# Altium schematic sheet AMU-S26-V00-DAUGHTERShutdown.SchDoc
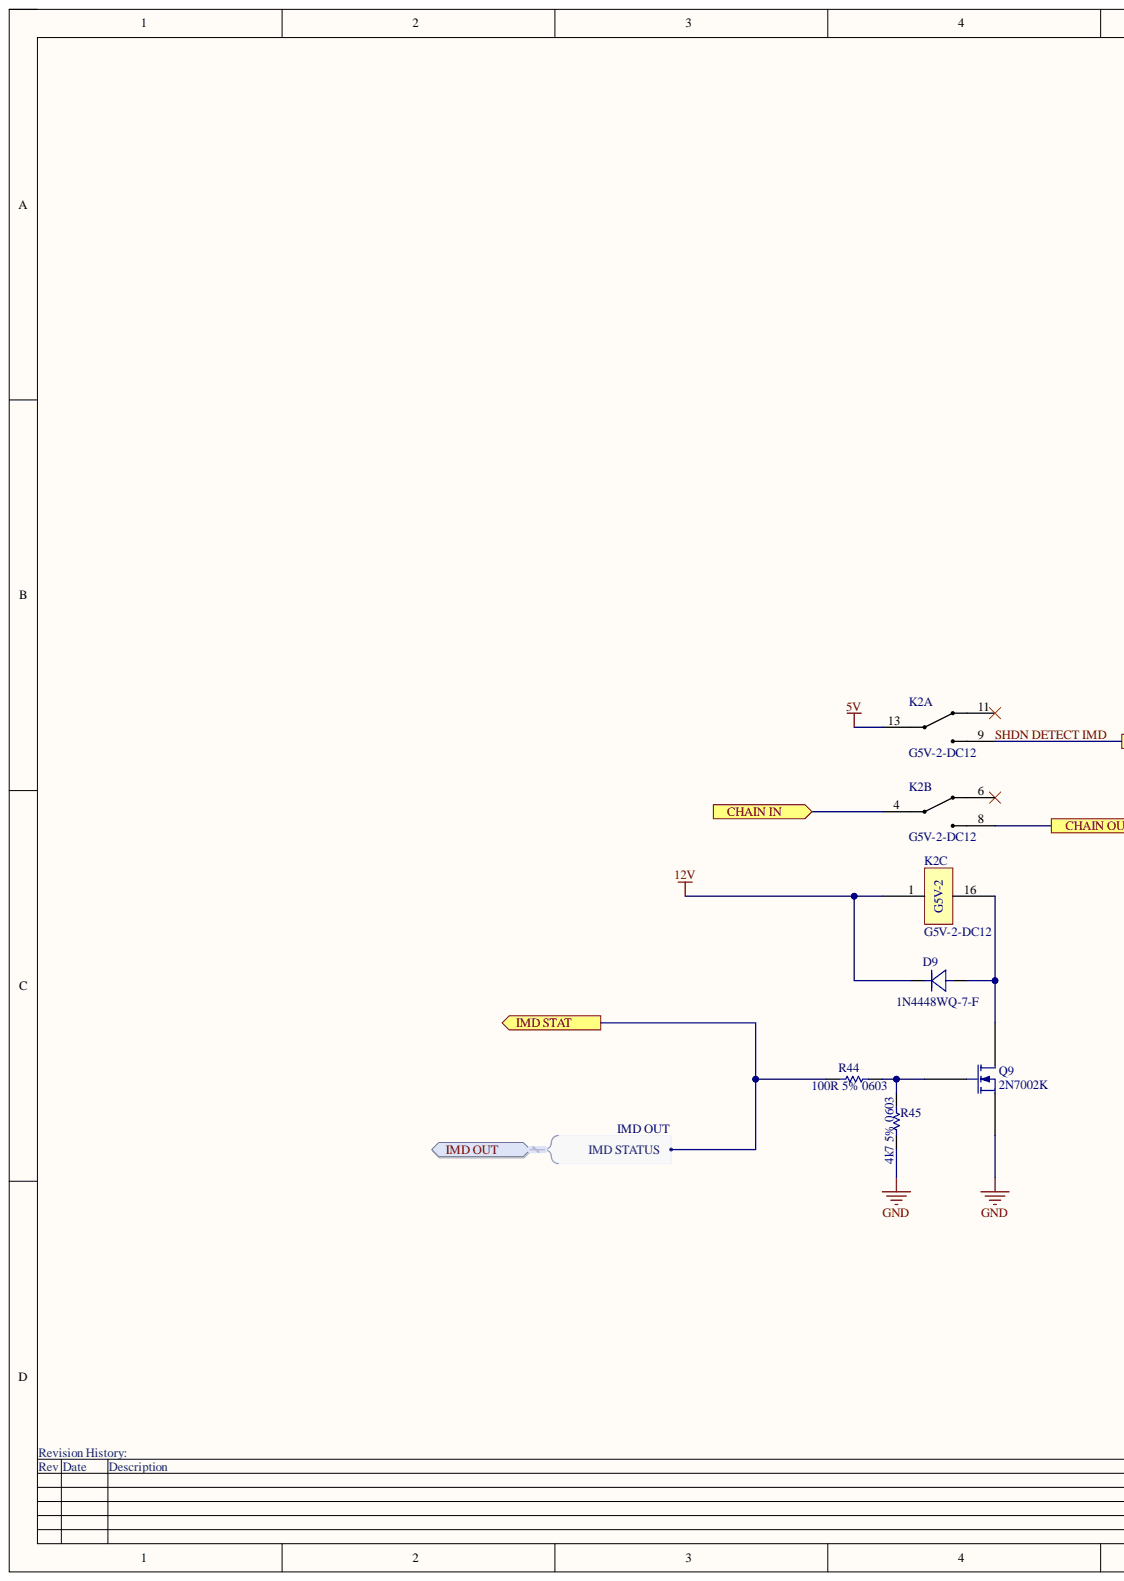
[diagram p0: AMU-S26-V00-DAUGHTERShutdown.SchDoc, left]
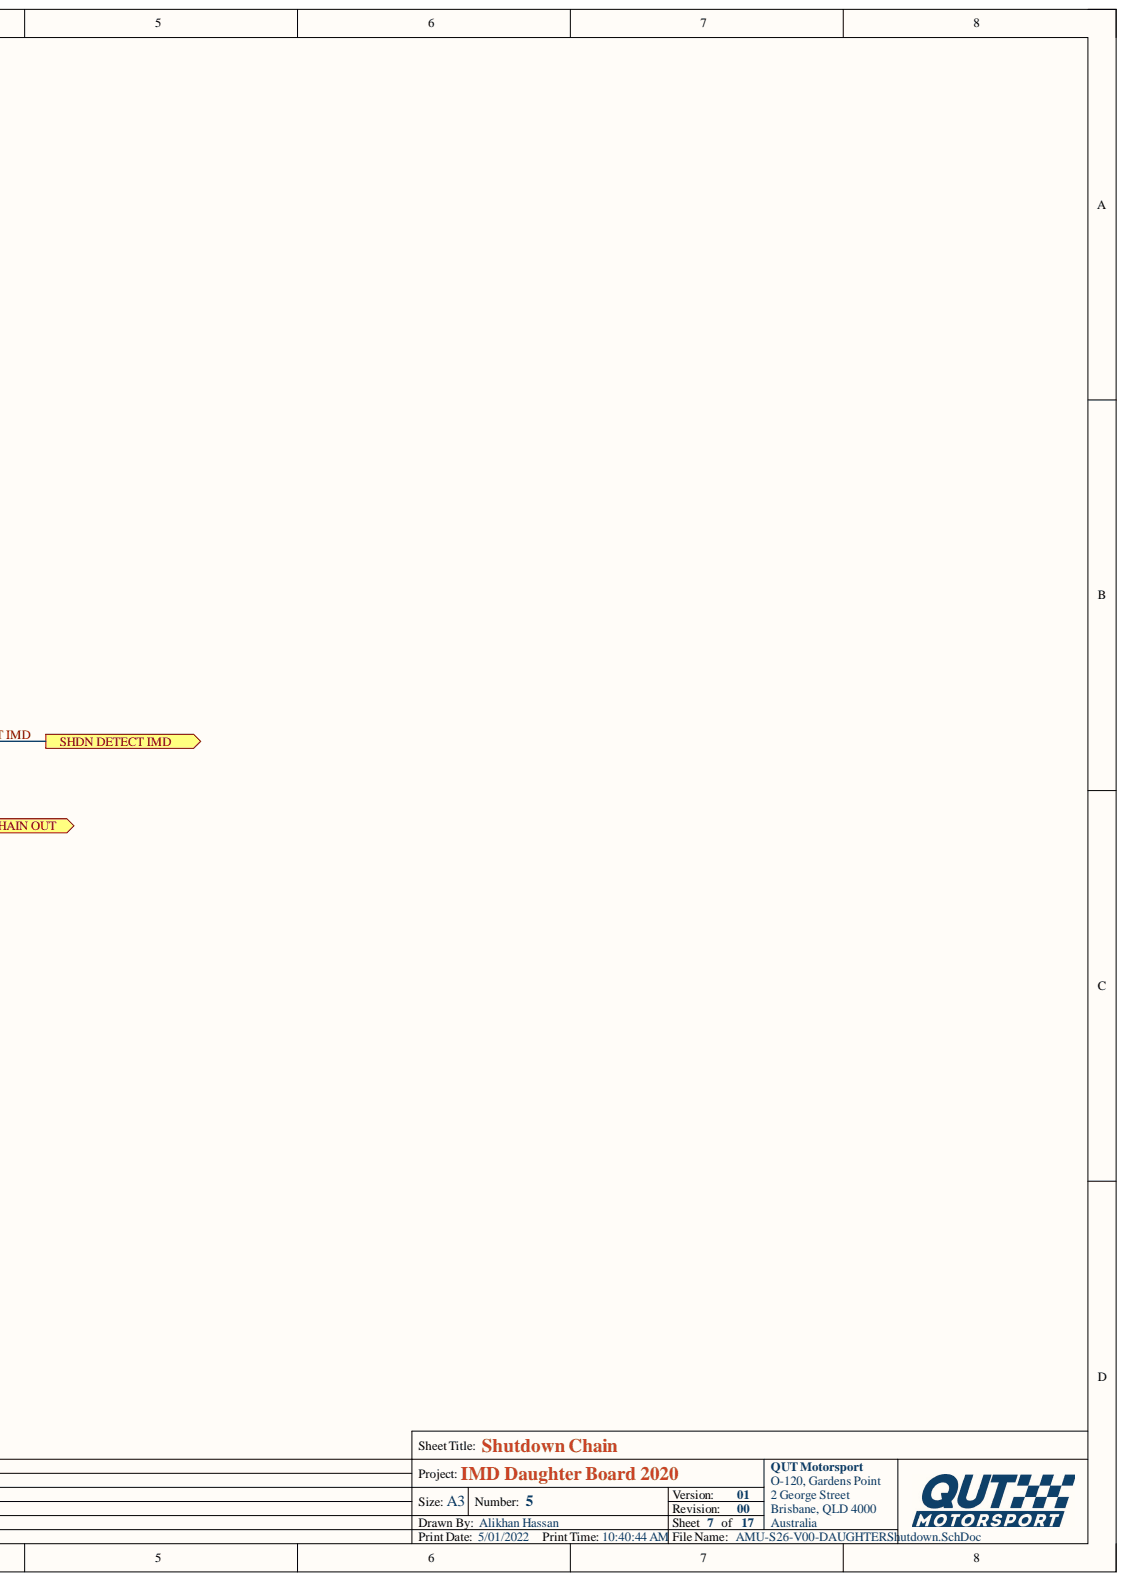
[diagram p1: AMU-S26-V00-DAUGHTERShutdown.SchDoc, right]

## Parts
D9  1N4448WQ-7-F  [SMA]
K2  G5V-2-DC12  [G5V-2]  (parts A,B,C)
Q9  2N7002K  [SOT-23-3]
R44  100R 5% 0603  [Res_ThinFilm_0603]
R45  4k7 5% 0603  [Res_ThinFilm_0603]

## Ports
CHAIN IN, CHAIN OUT, IMD OUT, IMD OUT.IMD MEAS LOW, IMD OUT.IMD MEASUREMENT, IMD OUT.IMD STATUS, IMD STAT, SHDN DETECT IMD

## Nets
5V: K2.13
12V: D9.K K2.1
GND: Q9.S R45.1
CHAIN IN: K2.4 ; port CHAIN IN
CHAIN OUT: K2.8 ; port CHAIN OUT
IMD OUT: R44.1 ; port IMD OUT, port IMD STAT
SHDN DETECT IMD: K2.9 ; port SHDN DETECT IMD
NetD9_A: D9.A K2.16 Q9.D
NetQ9_G: Q9.G R44.2 R45.2
N00001: ; port IMD OUT
N00002: ; port IMD OUT

Not connected: K2 6 11

## Library data of the parts
D9 = 1N4448WQ-7-F: Diode, SMD, 75V, 5%, 250mA, SOD123; Manufacturer: ON Semiconductor / Fairchild; Manufacturer Part Number: 1N914BWS; Average Rectified Current: 150mA; Element Configuration: Single; Forward Voltage: 1V; Max Forward Surge Current (Ifsm): 4A; Max Reverse Leakage Current: 5uA; Power Dissipation: 200mW; Recovery Time: 4ns; Category: Rectifier Diodes; Component Kind: Standard; Contact Plating: Tin,Matte; Forward Current: 150mA; Height: 1mm; Lead Free: Lead Free; Length: 1.8mm; Max Operating Temperature: 150°C; Max Repetitive Reverse Voltage (Vrrm): 75V; Max Reverse Voltage (DC): 75V; Min Operating Temperature: -55°C; Mount: Surface Mount; Number of Pins: 2; Package Quantity: 1; Packaging: Tape and Reel; Peak Reverse Current: 5uA; Radiation Hardening: No; REACH SVHC: No SVHC; Reverse Recovery Time: 4ns; Reverse Voltage: 75V; Weight: 0.0276g; Width: 1.35mm; Library Name: QUTMS_DiodeLibrary.SchLib
K2 = G5V-2-DC12: General Purpose Relay DPDT (2 Form C) Through Hole, 12V, 2A; Component Kind: Standard; Library Name: QUTMS_RelayLibrary.SchLib
Q9 = 2N7002K: N-Channel 60V 300mA (Ta) 350mW (Ta) Surface Mount SOT-23 (TO-236AB); Component Kind: Standard; Library Name: QUTMS_Transistors.SchLib
R44 = 100R 5%, 0603: Resistor, SMD, 100R, 0.1W, 5%, 0603; Component Kind: Standard; Library Name: QUTMS-Project-AMU.SchLib
R45 = 4k7 5% 0603: Resistor, SMD, 4k7, 0.1W, 5%, 0603; Component Kind: Standard; Library Name: QUTMS-Project-AMU.SchLib
